# Altium schematic sheet 1_A9912FH-PCB1-PFC_POWER_V1.SchDoc(1_A9912FH-PCB1-PFC_POWER_V1)
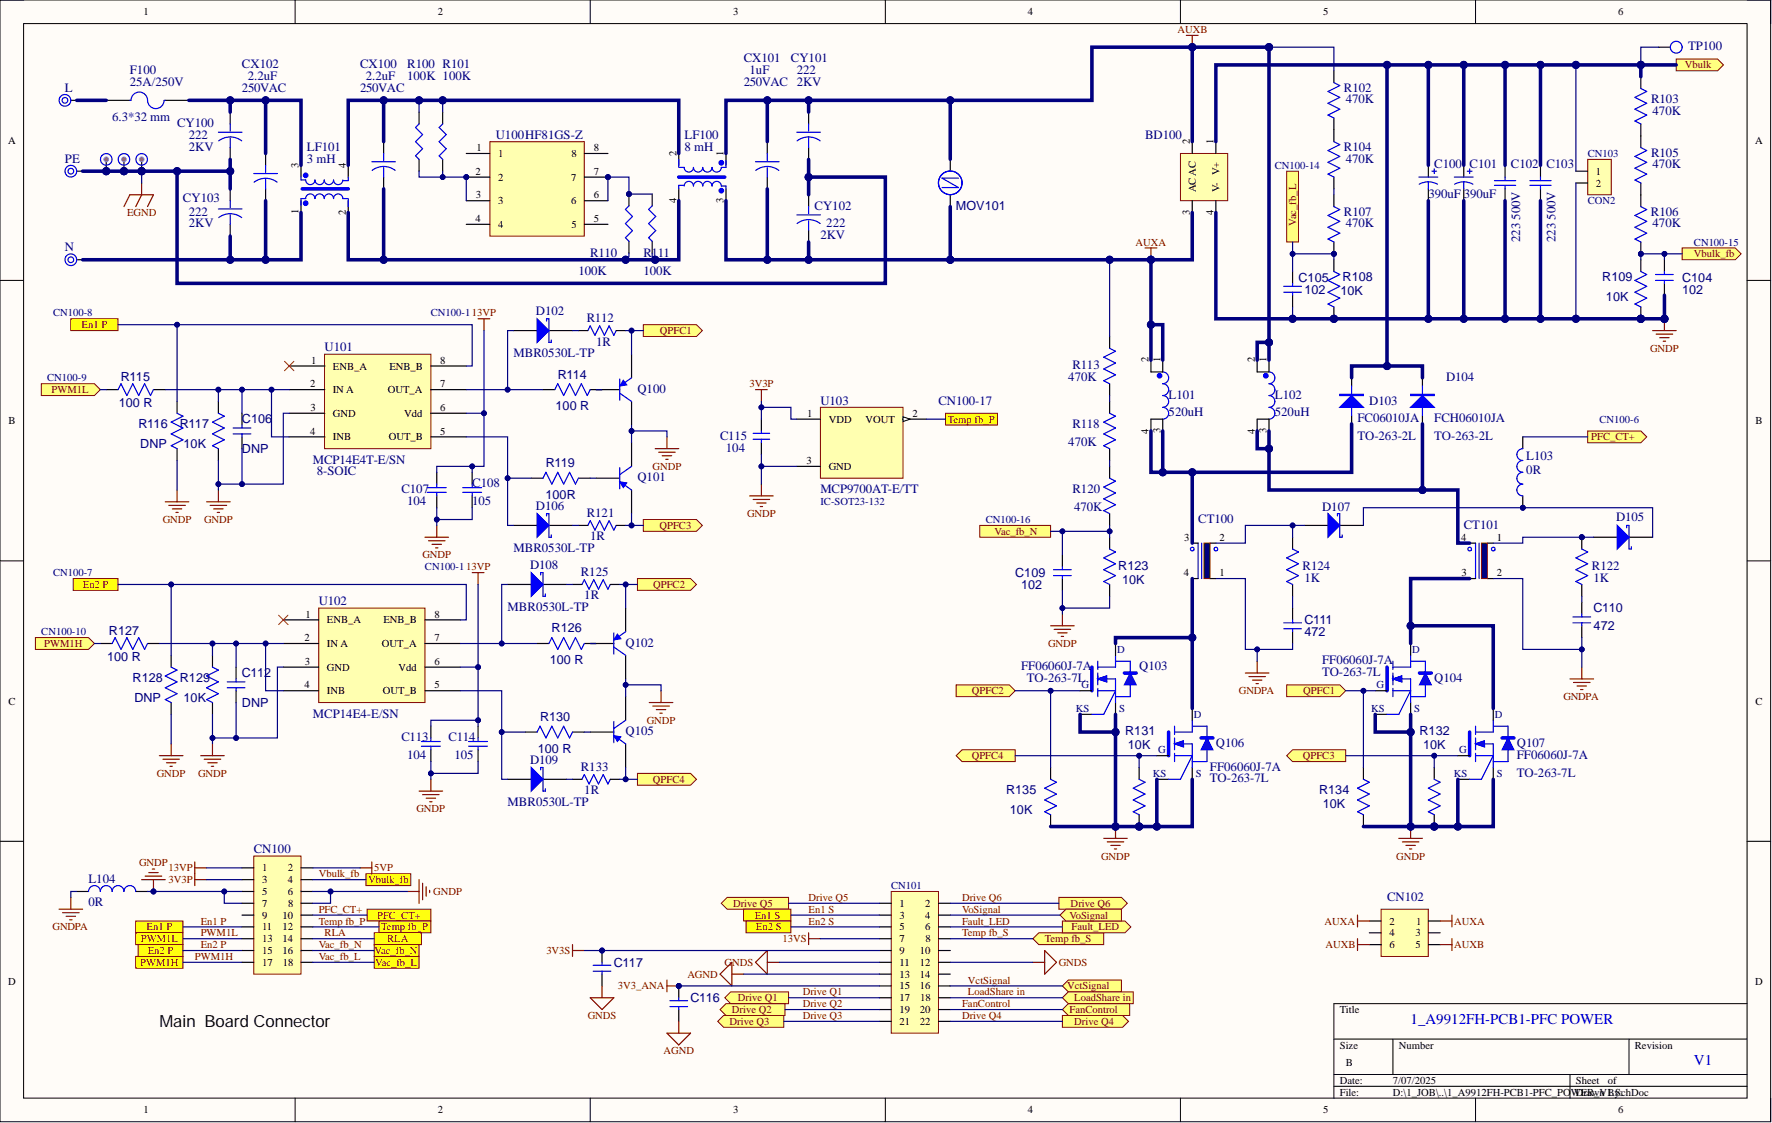
[diagram p0: 1_A9912FH-PCB1-PFC_POWER_V1.SchDoc]

## Parts
BD100  EGBU2506  [BD-GU1010-H]  (value not drawn)
C100  value 390uF  [CE-35R-P10_7]  (hidden comment: Cap  RADIAL 390UF_450V)
C101  value 390uF  [CE-35R-P10_7]  (hidden comment: Cap  RADIAL 390UF_450V)
C102  223 500V  [CS-1206S]
C103  223 500V  [CS-1206S]
C104  value 102  [CS-0805]  (hidden comment: CAP_RC0603)
C105  value 102  [CS-0805]  (hidden comment: CAP_RC0603)
C106  value DNP  [CS-0805]  (hidden comment: CAP_RC0603)
C107  104  [CS-0805]
C108  105  [CS-0805]
C109  value 102  [CS-0805]  (hidden comment: CAP_RC0603)
C110  value 472  [CS-0805]  (hidden comment: 0603_0.1uF_50V X7R)
C111  value 472  [CS-0805]  (hidden comment: 0603_0.1uF_50V X7R)
C112  value DNP  [CS-0805]  (hidden comment: CAP_RC0603)
C113  104  [CS-0805]
C114  105  [CS-0805]
C115  value 104  [CS-0805]  (hidden comment: 0603_0.1uF_50V X7R)
C116  0603_0.1uF_50V X7R  [CS-0805]  (value not drawn)
C117  0603_0.1uF_50V X7R  [CS-0805]  (value not drawn)
CN100  CON18D  [PND-P2_54-18]  (value not drawn)
CN101  CON22D  [PND-P2_54-22]  (value not drawn)
CN102  CON6D  [PND-P2_54-6]  (value not drawn)
CN103  CON2  [CN-L-P3_96-2]
CT100  (no value)  [LF-TR02689DIFF]
CT101  (no value)  [LF-TR02689DIFF]
CX100  2.2uF  [CX-26X13-P15/P22_5]
CX101  1uF  [CX-24_5X8-P15/P22_5]
CX102  2.2uF  [CX-26X13-P15/P22_5]
CY100  222  parameter 1: 2KV  [CY-15X5-P10]
CY101  222  parameter 1: 2KV  [CY-15X5-P10]
CY102  222  parameter 1: 2KV  [CY-15X5-P10]
CY103  222  parameter: 2KV  [CY-15X5-P10]
D102  Diode MBR0530L-TP  [DS-SOD123FL]  (value not drawn)
D103  FC06010JA  Parameter 1: TO-263-2L  [DS-TO263-KKA]
D104  FCH06010JA  Parameter 1: TO-263-2L  [DS-TO263-KKA]
D105  Diode BAT46WH,115  [DS-SOD123FL]  (value not drawn)
D106  Part Number: MBR0530L-TP  [DS-SOD123FL]  (hidden comment: Diode MBR0530L-TP)
D107  Diode BAT46WH,115  [DS-SOD123FL]  (value not drawn)
D108  Part Number: MBR0530L-TP  [DS-SOD123FL]  (hidden comment: Diode MBR0530L-TP)
D109  Part Number: MBR0530L-TP  [DS-SOD123FL]  (hidden comment: Diode MBR0530L-TP)
F100  25A/250V  Parameter 1: 6.3*32 mm  [FUSEHD-36X8_4]
J100  JP  [J-P15]  (value not drawn)
J101  JP  [J-P15]  (value not drawn)
L100  L  [AWG-AC-D2_1]
L101  520uH  [T-PQ3225H-8]
L102  520uH  [T-PQ3225H-8]
L103  value 0R  [LS-0805]  (hidden comment: Inductor)
L104  value 0R  [LS-0805]  (hidden comment: Inductor)
LF100  8 mH  [LF-LX23272-47X19-P13_5X18_5]
LF101  3 mH  [LF-LX23273-36X23-P10X16]
MH1  SCREW-HOLE  [MH8VIA-7_5R-D3_5]  (value not drawn)
MH2  SCREW-HOLE  [MH8VIA-7_5R-D3_5]  (value not drawn)
MH8  SCREW-HOLE  [MH8VIA-7_5R-D3_5]  (value not drawn)
MOV101  14D471  [VAR-14X4-P7_5]  (value not drawn)
N100  N  [AWG-AC-D2_1]
PE100  PE  [AWG-AC-D2_1]
Q100  MMBT3906  [Q-SOT23-BCE]  (value not drawn)
Q101  MMBT3906  [Q-SOT23-BCE]  (value not drawn)
Q102  MMBT3906  [Q-SOT23-BCE]  (value not drawn)
Q103  FF06060J-7A  parameter 1: TO-263-7L  [MOS-TO263-7L-GSD]
Q104  FF06060J-7A  parameter 1: TO-263-7L  [MOS-TO263-7L-GSD]
Q105  MMBT3906  [Q-SOT23-BCE]  (value not drawn)
Q106  FF06060J-7A  parameter 1: TO-263-7L  [MOS-TO263-7L-GSD]
Q107  FF06060J-7A  parameter 1: TO-263-7L  [MOS-TO263-7L-GSD]
R100  100K  [RS-1206S]
R101  100K  [RS-1206S]
R102  value 470K  [RS-1206S]  (hidden comment: 0805_470K)
R103  value 470K  [RS-1206S]  (hidden comment: 0805_470K)
R104  value 470K  [RS-1206S]  (hidden comment: 0805_470K)
R105  value 470K  [RS-1206S]  (hidden comment: 0805_470K)
R106  value 470K  [RS-1206S]  (hidden comment: 0805_470K)
R107  value 470K  [RS-1206S]  (hidden comment: 0805_470K)
R108  value 10K  [RS-0805]  (hidden comment: RC_0603)
R109  value 10K  [RS-0805]  (hidden comment: RC_0603)
R110  100K  [RS-1206S]
R111  100K  [RS-1206S]
R112  Resistance (Ohms): 1R  [RS-0805]  (hidden comment: RC0603JR-071RL)
R113  value 470K  [RS-1206S]  (hidden comment: 0805_470K)
R114  value 100 R  [RS-0805]  (hidden comment: RC_0603)
R115  value 100 R  [RS-0805]  (hidden comment: RC_0603)
R116  value DNP  [RS-0805]  (hidden comment: RC_0603)
R117  value 10K  [RS-0805]  (hidden comment: RC_0603)
R118  value 470K  [RS-1206S]  (hidden comment: 0805_470K)
R119  value 100R  [RS-0805]  (hidden comment: RC_0603)
R120  value 470K  [RS-1206S]  (hidden comment: 0805_470K)
R121  Resistance (Ohms): 1R  [RS-0805]  (hidden comment: RC0603JR-071RL)
R122  value 1K  [RS-0805]  (hidden comment: RC_0805)
R123  value 10K  [RS-0805]  (hidden comment: RC_0603)
R124  value 1K  [RS-0805]  (hidden comment: RC_0805)
R125  Resistance (Ohms): 1R  [RS-0805]  (hidden comment: RC0603JR-071RL)
R126  value 100 R  [RS-0805]  (hidden comment: RC_0603)
R127  value 100 R  [RS-0805]  (hidden comment: RC_0603)
R128  value DNP  [RS-0805]  (hidden comment: RC_0603)
R129  value 10K  [RS-0805]  (hidden comment: RC_0603)
R130  value 100 R  [RS-0805]  (hidden comment: RC_0603)
R131  value 10K  [RS-0805]  (hidden comment: RC_0603)
R132  value 10K  [RS-0805]  (hidden comment: RC_0603)
R133  Resistance (Ohms): 1R  [RS-0805]  (hidden comment: RC0603JR-071RL)
R134  value 10K  [RS-0805]  (hidden comment: RC_0603)
R135  value 10K  [RS-0805]  (hidden comment: RC_0603)
TP100  TP 66R42  [TP-1_5R - D1_0]  (value not drawn)  (no BOM)
U100  HF81GS-Z  [IC-SOP-P1_27-8]
U101  MCP14E4T-E/SN  Package / Case: 8-SOIC  [IC-SOP-P1_27-8]
U102  MCP14E4-E/SN  [IC-SOP-P1_27-8]
U103  MCP9700AT-E/TT  package: IC-SOT23-132  [IC-SOT23 -132]

## Ports
Drive Q1, Drive Q2, Drive Q3, Drive Q4, Drive Q5, Drive Q6, En1 P, En1 S, En2 P, En2 S, FanControl, Fault_LED, LoadShare in, PFC_CT+, PWM1H, PWM1L, QPFC1, QPFC2, QPFC3, QPFC4, RLA, Temp fb_P, Temp fb_S, Vac_fb_L, Vac_fb_N, Vbulk, Vbulk_fb, VctSignal, VoSignal

## Nets
3V3_ANA: C116.1 CN101.15
3V3P: C115.1 CN100.3 U103.1
3V3S: C117.1 CN101.9
5VP: CN100.2
13VP: C107.2 C108.2 C113.2 C114.2 CN100.1 U101.6(Vdd) U102.6(Vdd)
13VS: CN101.7
AGND: C116.2 CN101.13
AUXA: BD100.3(AC) CN102.1 CN102.2 CX101.2 CY102.2 L101.1 L101.2 LF100.3 MOV101.1 R113.1
AUXB: BD100.2(AC) CN102.5 CN102.6 CX101.1 CY101.1 L102.1 L102.2 LF100.1 MOV101.2 R102.1
EGND: CY100.2 CY101.2 CY102.1 CY103.1 MH1.1 MH2.1 MH8.1 PE100.1
GNDP: BD100.4(V-) C100.2 C101.2 C102.1 C103.1 C104.2 C105.2 C106.2 C107.1 C108.1 C109.2 C112.2 C113.1 C114.1 C115.2 CN100.5 CN100.6 CN100.7 CN100.8 CN103.2 J100.1 J101.1 L104.2 Q100.C Q101.C Q102.C Q103.KS Q103.S Q104.KS Q104.S Q105.C Q106.KS Q106.S Q107.KS Q107.S R108.2 R109.2 R116.2 R117.2 R123.2 R128.2 R129.2 R131.2 R132.2 R134.2 R135.2 U101.3(GND) U102.3(GND) U103.3
GNDPA: C110.2 C111.2 CT100.1 CT101.2 L104.1
GNDS: C117.2 CN101.11 CN101.12
Drive Q1: CN101.17 ; port Drive Q1
Drive Q2: CN101.19 ; port Drive Q2
Drive Q3: CN101.21 ; port Drive Q3
Drive Q4: CN101.22 ; port Drive Q4
Drive Q5: CN101.1 ; port Drive Q5
Drive Q6: CN101.2 ; port Drive Q6
En1 P: CN100.11 R116.1 U101.8(ENB_B) ; port En1 P
En1 S: CN101.3 ; port En1 S
En2 P: CN100.15 R128.1 U102.8(ENB_B) ; port En2 P
En2 S: CN101.5 ; port En2 S
FanControl: CN101.20 ; port FanControl
Fault_LED: CN101.6 ; port Fault_LED
LoadShare in: CN101.18 ; port LoadShare in
PFC_CT+: CN100.10 L103.2 ; port PFC_CT+
PWM1H: CN100.17 R127.1 ; port PWM1H
PWM1L: CN100.13 R115.1 ; port PWM1L
QPFC1: Q100.E Q104.G R112.2 R134.1 ; port QPFC1
QPFC2: Q102.E Q103.G R125.2 R135.1 ; port QPFC2
QPFC3: Q101.E Q107.G R121.2 R132.1 ; port QPFC3
QPFC4: Q105.E Q106.G R131.1 R133.2 ; port QPFC4
RLA: CN100.14 ; port RLA
Temp fb_P: CN100.12 U103.2 ; port Temp fb_P
Temp fb_S: CN101.8 ; port Temp fb_S
Vac_fb_L: C105.1 CN100.18 R107.2 R108.1 ; port Vac_fb_L
Vac_fb_N: C109.1 CN100.16 R120.2 R123.1 ; port Vac_fb_N
Vbulk: BD100.1(V+) C100.1 C101.1 C102.2 C103.2 CN103.1 D103.K D104.K R103.1 TP100.1 ; port Vbulk
Vbulk_fb: C104.1 CN100.4 R106.2 R109.1 ; port Vbulk_fb
VctSignal: CN101.16 ; port VctSignal
VoSignal: CN101.4 ; port VoSignal
NetC106_1: C106.1 R115.2 R117.1 U101.2(IN_A) U101.4(INB)
NetC110_1: C110.1 R122.2
NetC111_1: C111.1 R124.2
NetC112_1: C112.1 R127.2 R129.1 U102.2(IN_A) U102.4(INB)
NetCT100_2: CT100.2 D107.A R124.1
NetCT100_3: CT100.3 D103.A L101.3 L101.4
NetCT100_4: CT100.4 Q103.D Q106.D
NetCT101_1: CT101.1 D105.A R122.1
NetCT101_3: CT101.3 Q104.D Q107.D
NetCT101_4: CT101.4 D104.A L102.3 L102.4
NetCX100_1: CX100.1 LF100.2 LF101.4 R100.2 R101.2
NetCX100_2: CX100.2 LF100.4 LF101.2 R110.1 R111.1
NetCX102_1: CX102.1 CY100.1 F100.2 LF101.3
NetCX102_2: CX102.2 CY103.2 LF101.1 N100.1
NetD102_A: D102.A R114.1 U101.7(OUT_A)
NetD102_K: D102.K R112.1
NetD105_K: D105.K D107.K L103.1
NetD106_A: D106.A R119.1 U101.5(OUT_B)
NetD106_K: D106.K R121.1
NetD108_A: D108.A R126.1 U102.7(OUT_A)
NetD108_K: D108.K R125.1
NetD109_A: D109.A R130.1 U102.5(OUT_B)
NetD109_K: D109.K R133.1
NetF100_1: F100.1 L100.1
NetQ100_B: Q100.B R114.2
NetQ101_B: Q101.B R119.2
NetQ102_B: Q102.B R126.2
NetQ105_B: Q105.B R130.2
NetR100_1: R100.1 R101.1 U100.2 U100.3
NetR102_2: R102.2 R104.1
NetR103_2: R103.2 R105.1
NetR104_2: R104.2 R107.1
NetR105_2: R105.2 R106.1
NetR110_2: R110.2 R111.2 U100.6 U100.7
NetR113_2: R113.2 R118.1
NetR118_2: R118.2 R120.1

Not connected: CN100 9; CN101 10 14; CN102 3 4; U100 1 4 5 8; U101 1(ENB_A); U102 1(ENB_A)

## Library data of the parts
BD100 = BRIDGE: BD; Component Kind: Standard; Library Name: DIODE-SCH.SCHLIB
C100 C101 = Cap  RADIAL 330UF_450V: CAP ALUM 330UF 450V 20% SNAP; Part Number: LGL2W331MELB35; Vendor: Nichicon; Component Kind: Standard; Digikey: 493-7518-ND; Library Name: Capacitors.IntLib; Price: 10.18; Voltage: 450V
C102 = CAP: Chip capacitor. 22nF, 630V, 1206, X7R; Component Kind: Standard; Library Name: Components.SchLib; Voltage: 630V
C103 = CAP: Chip capacitor. 22nF, 630V, 1206, X7R; Voltage: 1206; Component Kind: Standard; Library Name: Components.SchLib
C104 C105 C109 = 0603_1nF_50V: CAP CER 1000PF 50V 20% X7R 0603; Part Number: C0603C102M5RACTU; Vendor: Kemet; Component Kind: Standard; Digikey: 399-7837-1-ND; Library Name: Capacitors.IntLib; Price: .0317
C106 C112 = 0603_No Load: CAP CERAMIC 0603 No Load; Component Kind: Standard; Library Name: Capacitors.IntLib; Price: 0
C107 C108 C113 C114 = Cap Semi: Capacitor (Semiconductor SIM Model); Component Kind: Standard; LatestRevisionDate: 29-May-2009; LatestRevisionNote: IPC-7351 Footprint Added.; Library Name: Miscellaneous Devices.IntLib; PackageDescription: Chip Capacitor; PackageReference: CAP0805; Published: 8-Jun-2000; Signal Integrity: Cap Semi; Simulation: CAP; Publisher: Altium Limited
  C107 C113: Value: 104
  C108 C114: Value: 105
C110 C111 C116 C117 = 0603_0.1uF_50V X7R: CAP CER 4.7nF 50V 10% X7R 0603; Part Number: C1608X7R1H104K; Vendor: TDK Corporation; Component Kind: Standard; Digikey: 445-1314-1-ND; Library Name: Capacitors.IntLib; Mouser: 810-C1608X7R1H104K; Price: .012
C115 = 0603_0.1uF_50V X7R: CAP CER 0.1UF 50V 10% X7R 0603; Part Number: C1608X7R1H104K; Vendor: TDK Corporation; Component Kind: Standard; Digikey: 445-1314-1-ND; Mouser: 810-C1608X7R1H104K; Price: 12
CN103 = CON2: CN; Component Kind: Standard; Library Name: CONNECT-SCH.SchLib
CT100 CT101 = T-AS101-2+FL2: Component Kind: Standard; Library Name: COIL-SCH.SCHLIB
CX100 CX101 = CAE-1: X-CAP 0.68uF/250V; comment_1: 250VAC; Component Kind: Standard; Library Name: A9911FH_V1.SCHLIB
CX102 = CAE-1: X-CAP 0.68uF/250V; parameter 1: 250VAC; Component Kind: Standard; Library Name: A9911FH_V1.SCHLIB
CY100-CY103 = CAE-1: X-CAP 0.68uF/250V; Component Kind: Standard; Library Name: A9911FH_V1.SCHLIB
D102 D106 D108 D109 = Diode MBR0530L-TP: DIODE SCHOTTKY 0.5A 30V SOD-123; Vendor: Micro Commercial Co; Component Kind: Standard; Digikey: MBR0530L-TPMSCT-ND; Library Name: Semiconductors SMD.IntLib; Price: .18
  D102: Part Number: MBR0530L-TP
D103 D104 = DIODE: Component Kind: Standard; Description: Diode; Library Name: Components.SchLib
D105 D107 = Diode BAT46WH,115: DIODE SCHOTTKY 100V 250MA SOD123; Vendor: NXP Semiconductors; Component Kind: Standard; Digikey: 568-6016-1-ND; Library Name: Semiconductors SMD.IntLib; Part Number: BAT46WH,115; Price: .1856
F100 = Fuse: Value: 6A,500V; Component Kind: Standard; Description: FUSE; LatestRevisionDate: 17-Jul-2002; LatestRevisionNote: Re-released for DXP Platform.; Library Name: Miscellaneous Devices.IntLib; Published: 8-Jun-2000; Simulation: FUSE; Publisher: Altium Limited
L100 N100 PE100 = PIN-AWG: Component Kind: Standard; Library Name: CONNECT-SCH.SchLib
L101 L102 = 330W PFC: Resonant Choke; Component Kind: Standard; Library Name: A9911FH-PCB1_V2.SCHLIB
L103 L104 = Inductor: Code_IEC: 1005; Code_JEITA: 0402; Component Kind: Standard; Description: Inductor; LatestRevisionDate: 29-May-2009; LatestRevisionNote: IPC-7351 Footprint Added.; Library Name: Miscellaneous Devices.IntLib; PackageDescription: Chip Inductor; PackageReference: IN0805_N; Published: 8-Jun-2000; Signal Integrity: Inductor; Simulation: INDUCTOR; Publisher: Altium Limited
LF100 LF101 = inductor LINE FILTER: CML; Component Kind: Standard; Library Name: Transformer.SchLib
MOV101 = MOV: Component Kind: Standard; Description: MOV; Library Name: DIP.IntLib; package: {Value}
Q100-Q102 Q105 = MMBT3906: TRANS PNP 40V 300MW SMD SOT23-3; Part Number: 3906-7-F; Vendor: Diodes Inc; Component Kind: Standard; Digikey: MMBT3906-FDICT-ND; Library Name: Semiconductors SMD.IntLib; Price: .08; Signal Integrity: MMBT3906; Simulation: QMMBT3906
Q103 Q104 Q106 Q107 = MOS-N-TO263-7L: Component Kind: Standard; Library Name: MOS&TRANSISTOR-SCH.SchLib
R100 R101 R110 R111 = Res: Chip resistor, 1M, 1% 1206; Parameter 1: 1206; Component Kind: Standard; Library Name: Components.SchLib
R102-R107 R113 R118 R120 = 0805_470K: RES 470K OHM 1/8W 1% 0805 SMD; Part Number: ERJ-6ENF4703V; Vendor: Panasonic Electronic Components; Component Kind: Standard; Digikey: P470KCCT-ND; Library Name: Resistors.IntLib; Price: .00768
R108 R109 R116 R117 R123 R128 R129 R131 R132 R134 R135 = 0603_10K: RES 10K OHM 1/10W 1% 0603 SMD; Part Number: RMCF 1/16 10K 1% R; Vendor: Stackpole Electronics Inc; Component Kind: Standard; Digikey: RMCF1/1610KFRTR-ND; Library Name: Resistors.IntLib; Price: .00228
  R117: Value: 10K
R112 R121 R125 R133 = RES0603 1R 5%: RES SMD 1 OHM 5% 1/10W 0603; Manufacturer: Yageo; Power (Watts): 0.1W, 1/10W; Temperature Coeffici: ±200ppm/°C; Category: Resistors; Component Kind: Standard; ComponentLink1Description: http://www.yageo.com/NewPortal/yageodocoutput?fileName=/pdf/R-Chip/PYu-RC_Group_51_RoHS_L_3.pdf; ComponentLink1URL: http://www.yageo.com/NewPortal/yageodocoutput?fileName=/pdf/R-Chip/PYu-RC_Group_51_RoHS_L_3.pdf; Composition: Thick Film; Features: Moisture Resistant; Height: 0.022" (0.55mm); Library Name: Resistor 0603.Schlib; Manufacturer Part Number: RC0603JR-071RL; Number of Terminations: 2; Operating Temperat: -55°C ~ 155°C; Package / Case: 0603 (1608 Metric); Packaging: Cut Tape (CT); Pricing 1: 1=0.1, 10=0.011, 25=0.008, 100=0.0044, 250=0.00336, 500=0.0027, 1000=0.00198, 2500=0.00172 (USD); RoHS: RoHS Compliant; Size / Dimension: 0.063" L x 0.031" W (1.60mm x 0.80mm); Stock 1: 346412; Supplier 1: Digi-Key; Supplier Device Package: 0603; Supplier Part Number 1: 311-1.0GRCT-ND; Tolerance: ±5%
R114 R115 R126 R127 = 0603_100 Ohm: RES 100 OHM 1/10W 1% 0603 SMD; Part Number: RMCF 1/16 100 1% R; Vendor: Stackpole Electronics Inc; Component Kind: Standard; Digikey: RMCF1/16100FRTR-ND; Library Name: Resistors.IntLib; Price: .00228
R119 = 0603_100 Ohm: RES 100 OHM 1/10W 1% 0603 SMD; Part Number: RMCF 1/16 100 1% R; Value: 100R; Vendor: Stackpole Electronics Inc; Component Kind: Standard; Digikey: RMCF1/16100FRTR-ND; Library Name: Resistors.IntLib; Price: .00228
R122 R124 = 0805_1K: RES 1K OHM 1/4W 1% 0805 SMD; Part Number: RNCP0805FTD1K00; Vendor: Stackpole Electronics Inc; Component Kind: Standard; Digikey: RNCP0805FTD1K00TR-ND; Library Name: Resistors.IntLib; Price: .00418
R130 = 0603_100 Ohm: RES 100 OHM 1/10W 1% 0603 SMD; Part Number: RMCF 1/16 100 1% R; Value: 100 R; Vendor: Stackpole Electronics Inc; Component Kind: Standard; Digikey: RMCF1/16100FRTR-ND; Library Name: Resistors.IntLib; Price: .00228
TP100 = Test Point 66R42 No Pin: Description: Test Point 66R42 No Pin; Library Name: Connector TH.IntLib
U100 = IC_8: Component Kind: Standard; Library Name: IC-SCH.SCHLIB
U101 U102 = MCP14E4-E/SN: IC MOSFET DVR 4.0A DUAL 8SOIC; Manufacturer: Microchip Technology; Configuration: Low-Side; Current - Peak: 4A; Delay Time: 46ns; Input Type: Non-Inverting; Number of Configurations: 2; Voltage - Supply: 4.5 V ~ 18 V; Category: Integrated Circuits (ICs); Component Kind: Standard; ComponentLink1Description: http://www.microchip.com/mymicrochip/filehandler.aspx?ddocname=en532049; ComponentLink1URL: http://www.microchip.com/mymicrochip/filehandler.aspx?ddocname=en532049; High Side Voltage - Max (Bootstrap): -; Mounting Type: Surface Mount; Number of Outputs: 2; Operating Temperat: -40°C ~ 125°C; Packaging: Tube; Pricing 1: 1=1.82, 25=1.51, 100=1.38 (USD); RoHS: RoHS Compliant; Stock 1: 7211; Supplier 1: Digi-Key; Supplier Device Package: 8-SOIC N; Library Name: SNM_Comp.SchLib
  U101: Manufacturer Part Number: MCP14E4T-E/SN; Supplier Part Number 1: MCP14E4-E/SN-ND
  U102: Manufacturer Part Number: MCP14E4-E/SN; Package / Case: 8-SOIC (0.154", 3.90mm Width); Supplier Part Number 1: MCP14E4-E/SN
U103 = MCP9700AT-E/TT: IC SENSOR THERMAL 2.3V SOT-23-3; Vendor: Microchip; Component Kind: Standard; Digikey: MCP9700AT-E/TTCT-ND; Part Number: MCP9700AT-E/TT; Price: 24
CN100 = CON18D: Component Kind: Standard
CN101 = CON22D: Component Kind: Standard; Library Name: CONNECT-SCH.SchLib
CN102 = CON6D: Component Kind: Standard; Library Name: CONNECT-SCH.SchLib
J100 J101 = JP: Component Kind: Standard; Library Name: OTHER-SCH.SCHLIB
MH1 MH2 MH8 = SCREW-HOLE: Component Kind: Standard; Library Name: OTHER-SCH.SCHLIB
